# Altium schematic sheet wls2-dcmagnet.SchDoc(wls2-dcmagnet)
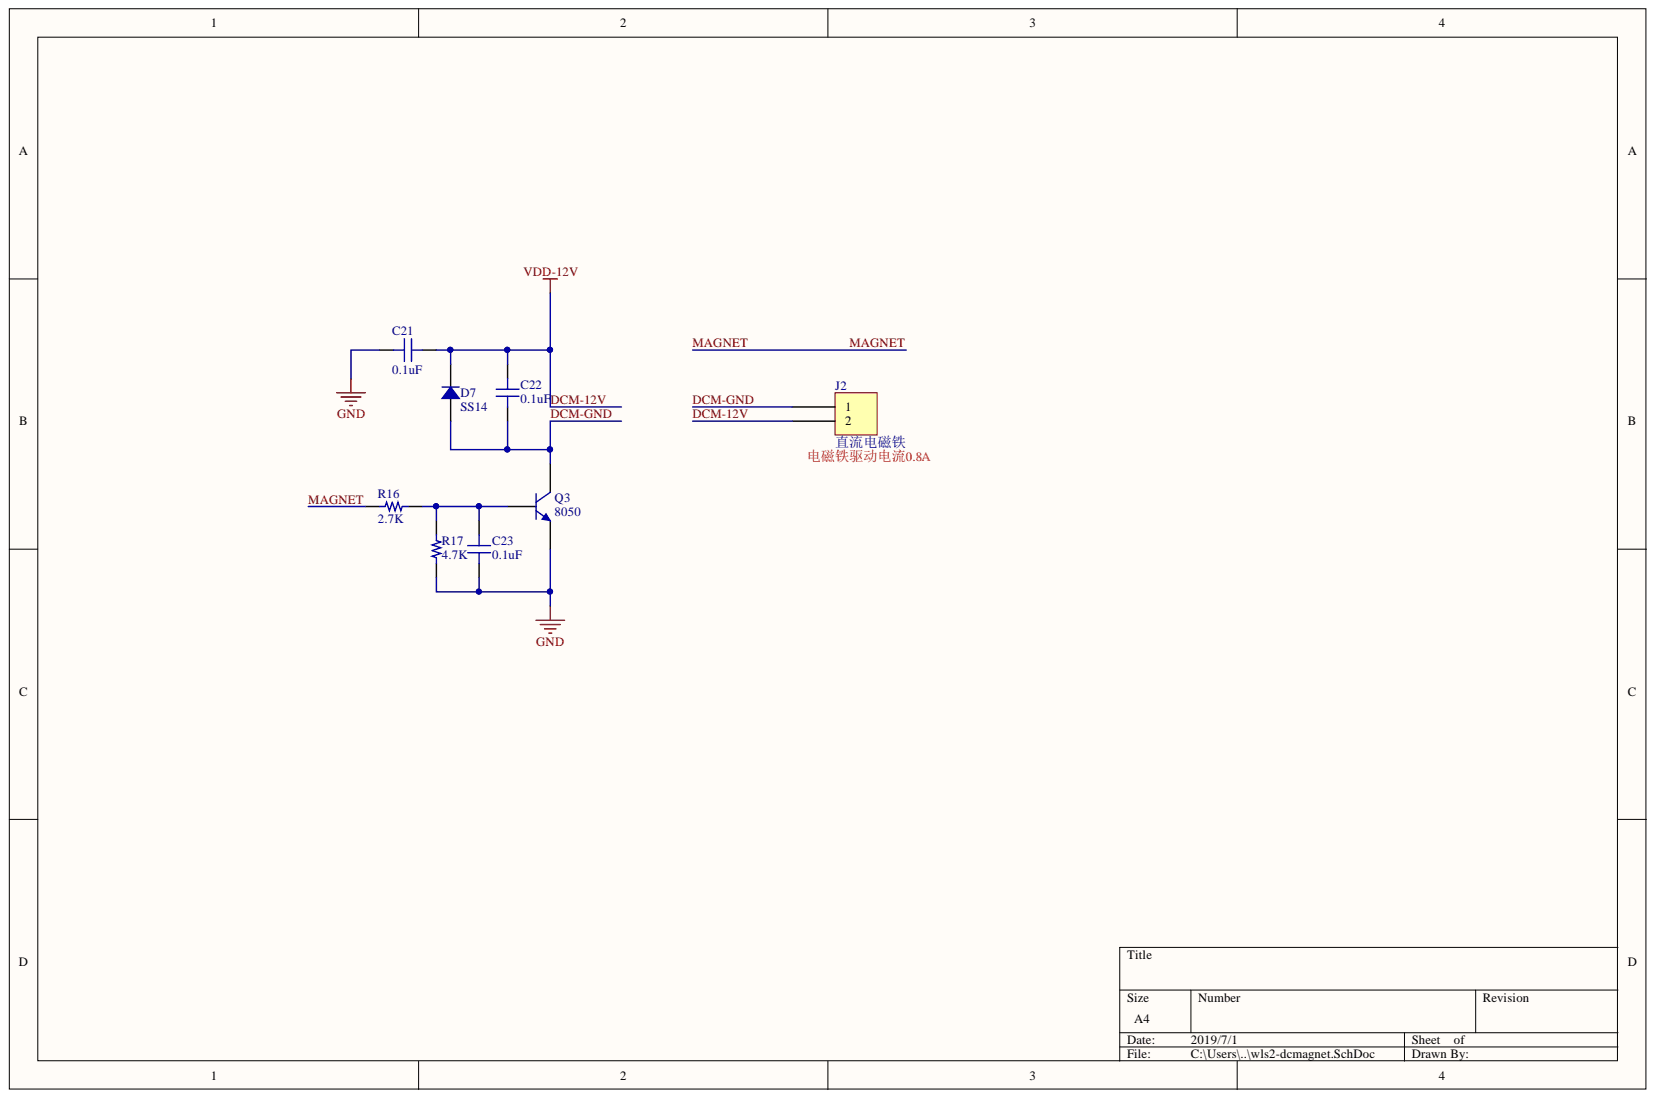
[diagram p0: wls2-dcmagnet.SchDoc]

## Parts
C21  0.1uF  [RES 0402/1005]
C22  0.1uF  [RES 0402/1005]
C23  0.1uF  [RES 0402/1005]
D7  SS14  [SMA-DO214AC]
J2  直流电磁铁  [PH2.0-2PIN_JST]
Q3  8050  [SOT23]
R16  2.7K  [RES 0402/1005]
R17  4.7K  [RES 0402/1005]

## Nets
GND: C21.2 C23.1 Q3.2 R17.1
DCM-12V: C21.1 C22.2 D7.2 J2.2
DCM-GND: C22.1 D7.1 J2.1 Q3.3
MAGNET: R16.1
NetC23_2: C23.2 Q3.1 R16.2 R17.2

## Library data of the parts
C21-C23 = Capacitor: SMT Capacitor; Component Kind: Standard; Library Name: Passives.SchLib; Value: 0.1uF
D7 = Diode: Rectifier Diode; Component Kind: Standard; Library Name: Diodes.SchLib; Part Number: SS14
J2 = 2 Pin: Component Kind: Standard; Library Name: Connectors.SchLib
R16 R17 = Resistor: SMT Resistor; Component Kind: Standard; Library Name: Passives.SchLib; TYPE: 1%
  R16: Value: 2.7K
  R17: Value: 4.7K
Q3 = 8050: Component Kind: Standard; Library Name: Footprint.SchLib
